# Altium schematic sheet BM20_Rev0_EMC.SchDoc
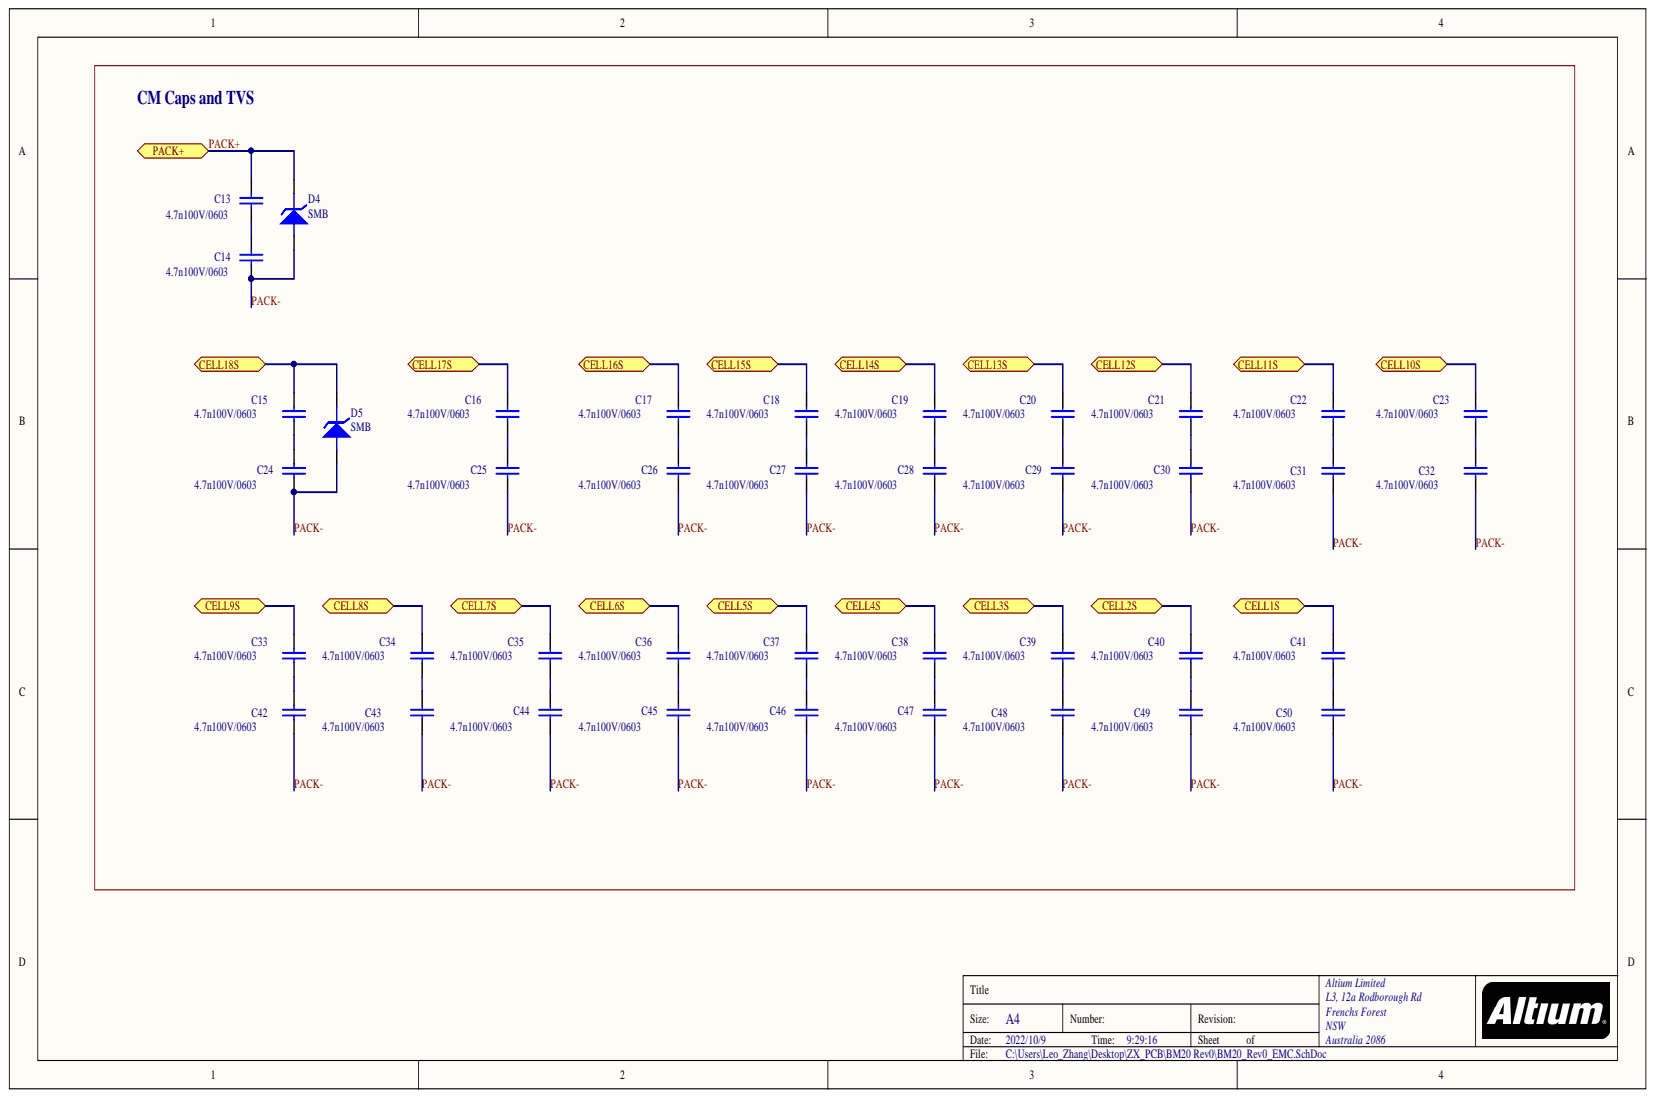
[diagram p0: BM20_Rev0_EMC.SchDoc]

## Parts
C13  4.7n100V/0603  [0603_C]
C14  4.7n100V/0603  [0603_C]
C15  4.7n100V/0603  [0603_C]
C16  4.7n100V/0603  [0603_C]
C17  4.7n100V/0603  [0603_C]
C18  4.7n100V/0603  [0603_C]
C19  4.7n100V/0603  [0603_C]
C20  4.7n100V/0603  [0603_C]
C21  4.7n100V/0603  [0603_C]
C22  4.7n100V/0603  [0603_C]
C23  4.7n100V/0603  [0603_C]
C24  4.7n100V/0603  [0603_C]
C25  4.7n100V/0603  [0603_C]
C26  4.7n100V/0603  [0603_C]
C27  4.7n100V/0603  [0603_C]
C28  4.7n100V/0603  [0603_C]
C29  4.7n100V/0603  [0603_C]
C30  4.7n100V/0603  [0603_C]
C31  4.7n100V/0603  [0603_C]
C32  4.7n100V/0603  [0603_C]
C33  4.7n100V/0603  [0603_C]
C34  4.7n100V/0603  [0603_C]
C35  4.7n100V/0603  [0603_C]
C36  4.7n100V/0603  [0603_C]
C37  4.7n100V/0603  [0603_C]
C38  4.7n100V/0603  [0603_C]
C39  4.7n100V/0603  [0603_C]
C40  4.7n100V/0603  [0603_C]
C41  4.7n100V/0603  [0603_C]
C42  4.7n100V/0603  [0603_C]
C43  4.7n100V/0603  [0603_C]
C44  4.7n100V/0603  [0603_C]
C45  4.7n100V/0603  [0603_C]
C46  4.7n100V/0603  [0603_C]
C47  4.7n100V/0603  [0603_C]
C48  4.7n100V/0603  [0603_C]
C49  4.7n100V/0603  [0603_C]
C50  4.7n100V/0603  [0603_C]
D4  SMB  [SMB/DO-214AA]
D5  SMB  [SMB/DO-214AA]

## Ports
CELL1S, CELL2S, CELL3S, CELL4S, CELL5S, CELL6S, CELL7S, CELL8S, CELL9S, CELL10S, CELL11S, CELL12S, CELL13S, CELL14S, CELL15S, CELL16S, CELL17S, CELL18S, PACK+

## Nets
CELL1S: C41.2 ; port CELL1S
CELL2S: C40.2 ; port CELL2S
CELL3S: C39.2 ; port CELL3S
CELL4S: C38.2 ; port CELL4S
CELL5S: C37.2 ; port CELL5S
CELL6S: C36.2 ; port CELL6S
CELL7S: C35.2 ; port CELL7S
CELL8S: C34.2 ; port CELL8S
CELL9S: C33.2 ; port CELL9S
CELL10S: C23.2 ; port CELL10S
CELL11S: C22.2 ; port CELL11S
CELL12S: C21.2 ; port CELL12S
CELL13S: C20.2 ; port CELL13S
CELL14S: C19.2 ; port CELL14S
CELL15S: C18.2 ; port CELL15S
CELL16S: C17.2 ; port CELL16S
CELL17S: C16.2 ; port CELL17S
CELL18S: C15.2 D5.K ; port CELL18S
PACK+: C13.2 D4.K ; port PACK+
PACK-: C14.1 C24.1 C25.1 C26.1 C27.1 C28.1 C29.1 C30.1 C31.1 C32.1 C42.1 C43.1 C44.1 C45.1 C46.1 C47.1 C48.1 C49.1 C50.1 D4.A D5.A
NetC13_1: C13.1 C14.2
NetC15_1: C15.1 C24.2
NetC16_1: C16.1 C25.2
NetC17_1: C17.1 C26.2
NetC18_1: C18.1 C27.2
NetC19_1: C19.1 C28.2
NetC20_1: C20.1 C29.2
NetC21_1: C21.1 C30.2
NetC22_1: C22.1 C31.2
NetC23_1: C23.1 C32.2
NetC33_1: C33.1 C42.2
NetC34_1: C34.1 C43.2
NetC35_1: C35.1 C44.2
NetC36_1: C36.1 C45.2
NetC37_1: C37.1 C46.2
NetC38_1: C38.1 C47.2
NetC39_1: C39.1 C48.2
NetC40_1: C40.1 C49.2
NetC41_1: C41.1 C50.2

## Library data of the parts
C13-C50 = capacitor: 贴片电容; Component Kind: Standard; Library Name: Schlib1.SchLib
D4 D5 = DIODE ZENER: 稳压二极管; Component Kind: Standard; Library Name: BM02 Rev0.SchLib
